# Altium schematic sheet Power.SchDoc(Power)
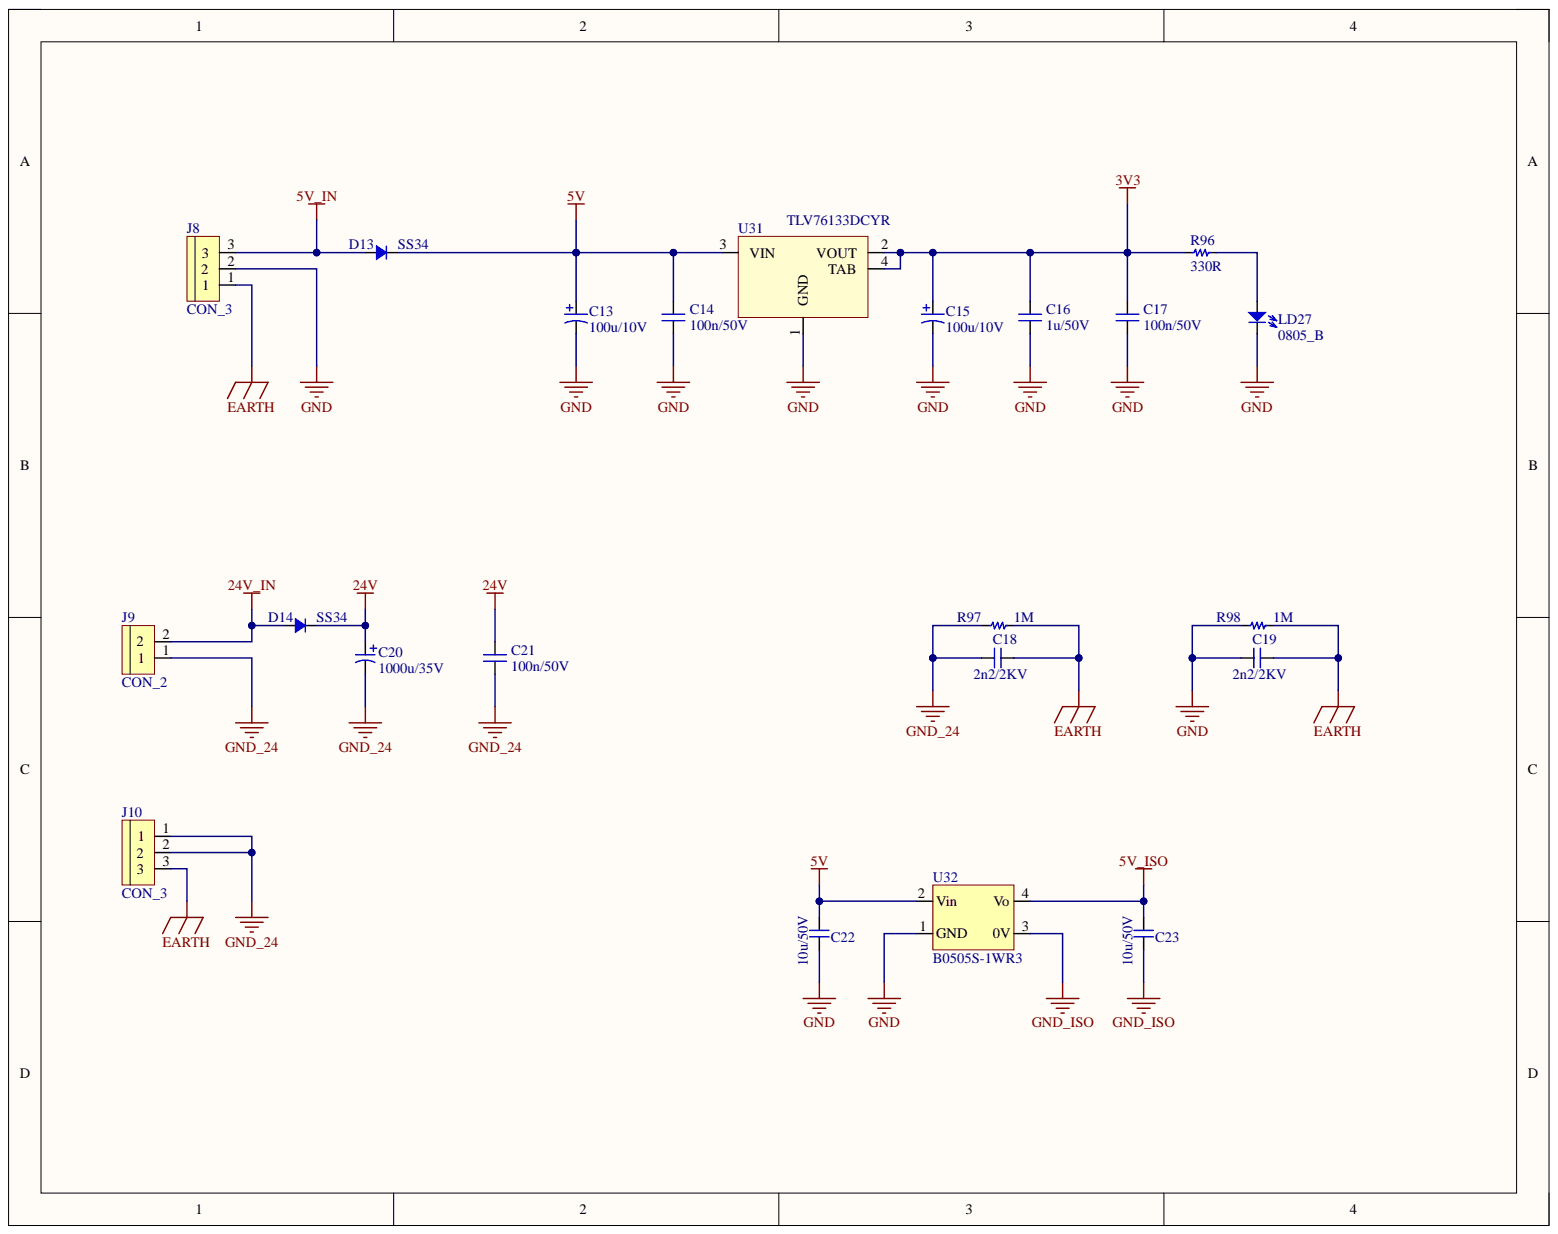
[diagram p0: Power.SchDoc]

## Parts
C13  100u/10V  [CAP_TANTA_CASE_B]
C14  100n/50V  [C-0805]
C15  100u/10V  [CAP_TANTA_CASE_B]
C16  1u/50V  [C-0805]
C17  100n/50V  [C-0805]
C18  2n2/2KV  [CAP_Y2_222_P5]
C19  2n2/2KV  [CAP_Y2_222_P5]
C20  1000u/35V  [CAP_ALUM_D100_F50]
C21  100n/50V  [C-0805]
C22  10u/50V  [C-0805]
C23  10u/50V  [C-0805]
D13  SS34  [SMA]
D14  SS34  [SMA]
J8  CON_3  [KF-5.0-3P]
J9  CON_2  [KF-5.0-2P]
J10  CON_3  [KF-5.0-3P]
LD27  0805_B  [LED_0805_B]
R96  330R  [R-0805]
R97  1M  [R-1206]
R98  1M  [R-1206]
U31  TLV76133DCYR  [SOT-223]
U32  B0505S-1WR3  [B0505S-1W]

## Nets
3V3: C15.1 C16.1 C17.1 R96.1 U31.2(VOUT) U31.4(TAB)
5V: C13.1 C14.1 C22.2 D13.2 U31.3(VIN) U32.2(Vin)
5V_IN: D13.1 J8.3
5V_ISO: C23.2 U32.4(Vo)
24V: C20.1 C21.1 D14.2
24V_IN: D14.1 J9.2
EARTH: C18.2 C19.2 J8.1 J10.3 R97.2 R98.2
GND: C13.2 C14.2 C15.2 C16.2 C17.2 C19.1 C22.1 J8.2 LD27.2 R98.1 U31.1(GND) U32.1(GND)
GND_24: C18.1 C20.2 C21.2 J9.1 J10.1 J10.2 R97.1
GND_ISO: C23.1 U32.3(0V)
NetLD27_1: LD27.1 R96.2

## Library data of the parts
C13 C15 = TANTALUM_CAPACITOR: Tantalum Capacitors; Component Kind: Standard; Library Name: Schlib1.SchLib
C14 C16 C17 C21 = CAPACITOR: Multilayer Ceramic Capacitors; Component Kind: Standard; Library Name: Schlib1.SchLib
C18 C19 C22 C23 = CERAMIC_CAP: Component Kind: Standard; Library Name: NXVu.SchLib
C20 = ALUMINUM CAPACITOR: Component Kind: Standard; Library Name: NXVu.SchLib
D13 D14 = DIODE: Component Kind: Standard; Library Name: NXVu.SchLib
LD27 = LED_0805_B: Component Kind: Standard; Library Name: NXVu.SchLib
R96-R98 = RESISTER: Thick Film Resistors; Component Kind: Standard; Library Name: Schlib1.SchLib
U31 = LM1117: Component Kind: Standard; Library Name: NXVu.SchLib
J8 J10 = CON_3: Component Kind: Standard; Library Name: NXVu.SchLib
J9 = CON_2: Component Kind: Standard; Library Name: NXVu.SchLib
U32 = B0505S-1WR3: Component Kind: Standard; Library Name: NXVu.SchLib
